# Altium schematic sheet 14_PMU.SchDoc
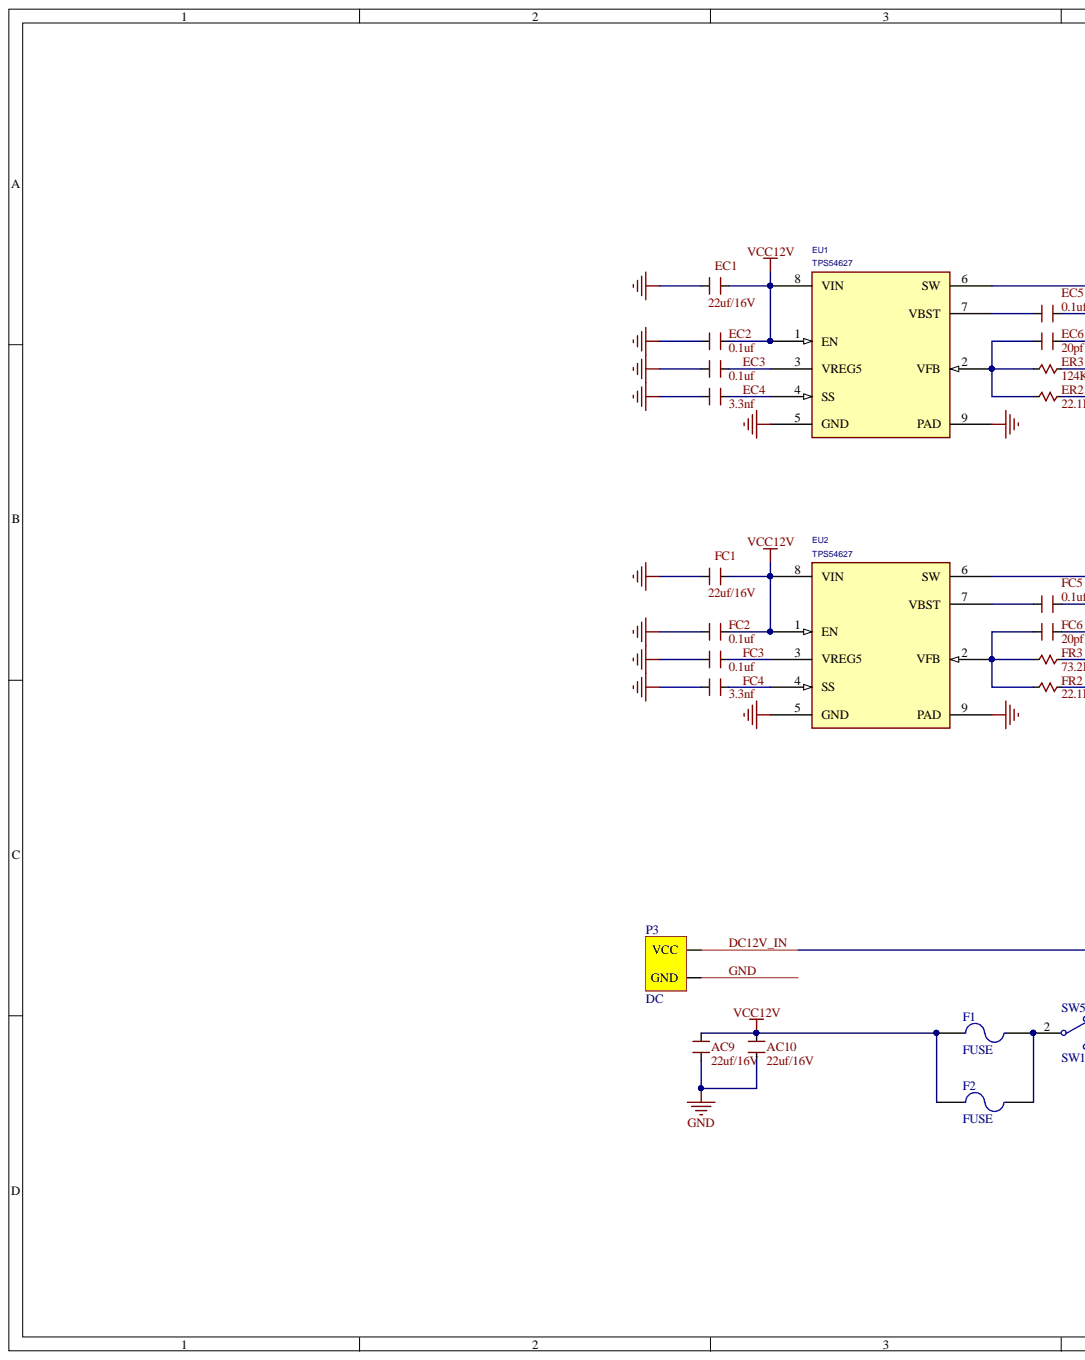
[diagram p0: 14_PMU.SchDoc, left]
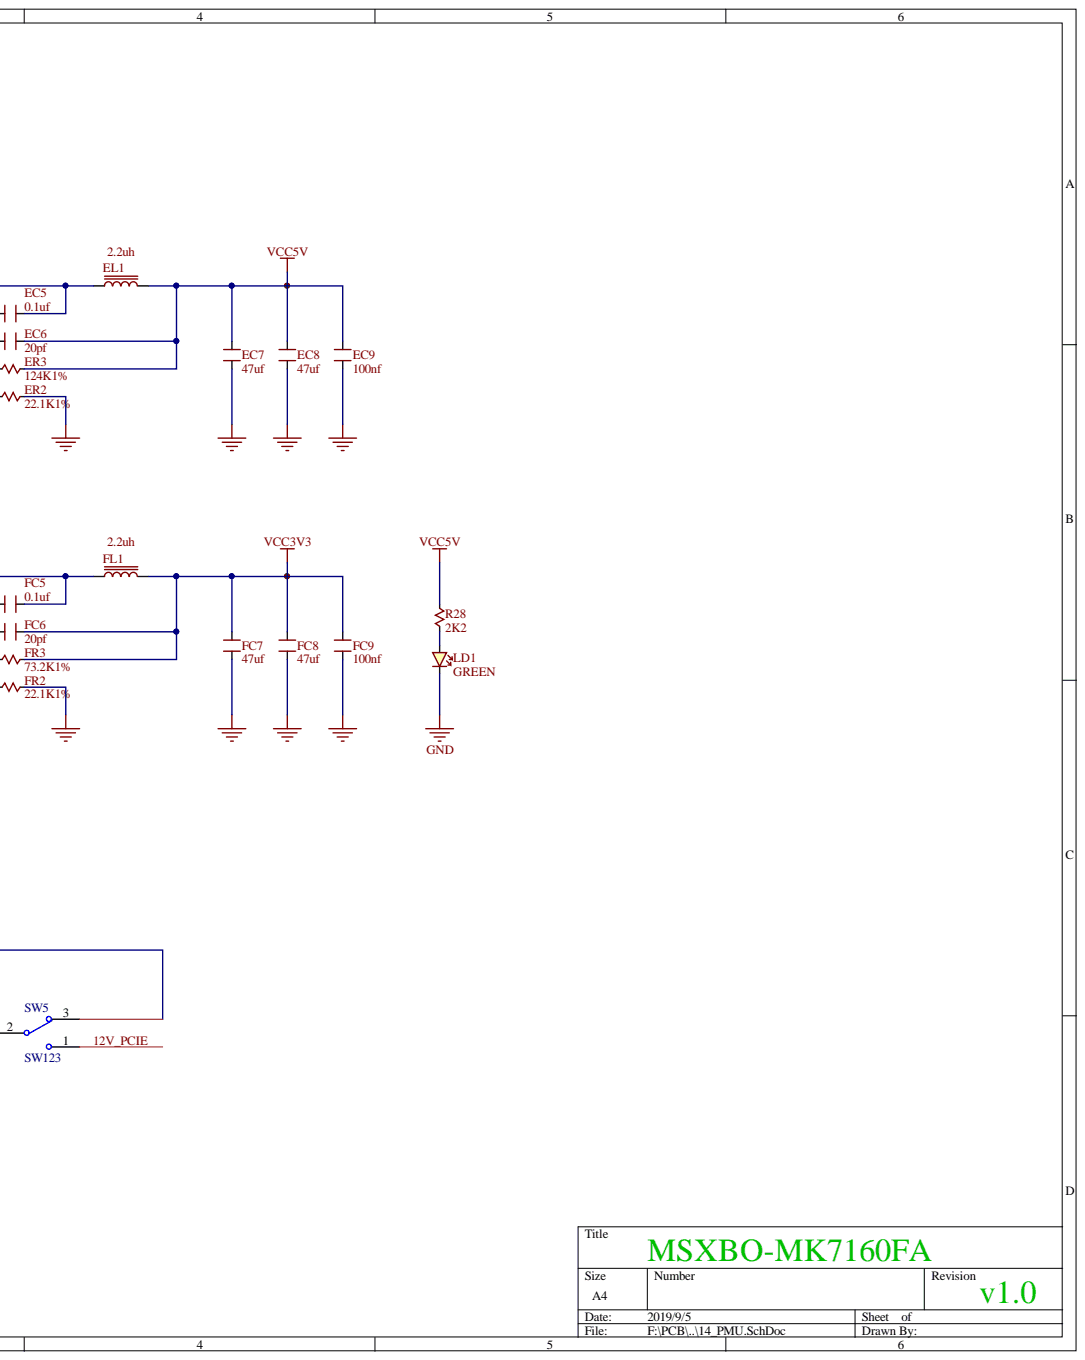
[diagram p1: 14_PMU.SchDoc, right]

## Parts
AC9  22uf/16V  [CAP1206]
AC10  22uf/16V  [CAP1206]
EC1  22uf/16V  [CAP0603]
EC2  0.1uf  [CAP0402]
EC3  0.1uf  [CAP0402]
EC4  3.3nf  [CAP0402]
EC5  0.1uf  [CAP0402]
EC6  20pf  [CAP0402]
EC7  47uf  [CAP0603]
EC8  47uf  [CAP0603]
EC9  100nf  [CAP0402]
EL1  2.2uh  [L4X4X2]
ER2  22.1K1%  [CAP0603]
ER3  124K1%  [CAP0603]
EU1  TPS54627  [SOP8_EP_127P4X5X1_5]
EU2  TPS54627  [SOP8_EP_127P4X5X1_5]
F1  FUSE  [1206]
F2  FUSE  [1206]
FC1  22uf/16V  [CAP0603]
FC2  0.1uf  [CAP0402]
FC3  0.1uf  [CAP0402]
FC4  3.3nf  [CAP0402]
FC5  0.1uf  [CAP0402]
FC6  20pf  [CAP0402]
FC7  47uf  [CAP0603]
FC8  47uf  [CAP0603]
FC9  100nf  [CAP0402]
FL1  2.2uh  [L4X4X2]
FR2  22.1K1%  [CAP0603]
FR3  73.2K1%  [CAP0603]
LD1  value GREEN  [D0603]  (hidden comment: LED)
P3  DC  [DC5.0]
R28  2K2  [RES0402]
SW5  SW123  [SW1-2-3X2]

## Nets
VCC3V3: FC6.2 FC7.2 FC8.2 FC9.2 FL1.2 FR3.1
VCC5V: EC6.2 EC7.2 EC8.2 EC9.2 EL1.2 ER3.1 R28.1
VCC12V: AC9.2 AC10.2 EC1.2 EC2.2 EU1.1(EN) EU1.8(VIN) EU2.1(EN) EU2.8(VIN) F1.1 F2.1 FC1.2 FC2.2
12V_PCIE: SW5.1
DC12V_IN: P3.1 SW5.3
GND: AC9.1 AC10.1 EC1.1 EC2.1 EC3.1 EC4.1 EC7.1 EC8.1 EC9.1 ER2.1 EU1.5(GND) EU1.9(PAD) EU2.5(GND) EU2.9(PAD) FC1.1 FC2.1 FC3.1 FC4.1 FC7.1 FC8.1 FC9.1 FR2.1 LD1.K P3.2
NetEC3_2: EC3.2 EU1.3(VREG5)
NetEC4_2: EC4.2 EU1.4(SS)
NetEC5_1: EC5.1 EU1.7(VBST)
NetEC5_2: EC5.2 EL1.1 EU1.6(SW)
NetEC6_1: EC6.1 ER2.2 ER3.2 EU1.2(VFB)
NetEU2_2: EU2.2(VFB) FC6.1 FR2.2 FR3.2
NetEU2_3: EU2.3(VREG5) FC3.2
NetEU2_4: EU2.4(SS) FC4.2
NetEU2_6: EU2.6(SW) FC5.2 FL1.1
NetEU2_7: EU2.7(VBST) FC5.1
NetF1_2: F1.2 F2.2 SW5.2
NetLD1_A: LD1.A R28.2

## Library data of the parts
AC9 AC10 = CAPACITOR: Generic Capacitor; Value: 10nF; Component Kind: Standard; Library Name: MIZ7035_定制.IntLib
EC1-EC9 FC1-FC9 = CAPACITOR: Generic Capacitor; Component Kind: Standard; Library Name: Miz702fun_20160501.IntLib
EL1 FL1 = Inductor: Generic Inductor; Component Kind: Standard; Library Name: Digilent.IntLib
ER2 ER3 FR2 FR3 = RESISTOR: Generic Resistor; Value: 4.7K; Component Kind: Standard; Library Name: Digilent.IntLib
EU1 EU2 = TPS54327: Component Kind: Standard
F1 F2 = Fuse 2: Component Kind: Standard; Description: Fuse; LatestRevisionDate: 17-Jul-2002; LatestRevisionNote: Re-released for DXP Platform.; PackageReference: PIN-W2/E2.8; Published: 8-Jun-2000; Simulation: FUSE; Library Name: jinrylib.IntLib; Publisher: Altium Limited
LD1 = LED: Generic LED; Component Kind: Standard; Library Name: Digilent.IntLib
R28 = RESISTOR: Generic Resistor; Value: 390; Component Kind: Standard; Library Name: Digilent.IntLib
P3 = DC: Component Kind: Standard; Description: DC; Library Name: jinrylib.IntLib
SW5 = SW123: Component Kind: Standard; Library Name: jinrylib.IntLib
